# Altium schematic sheet Power.SchDot(Power)
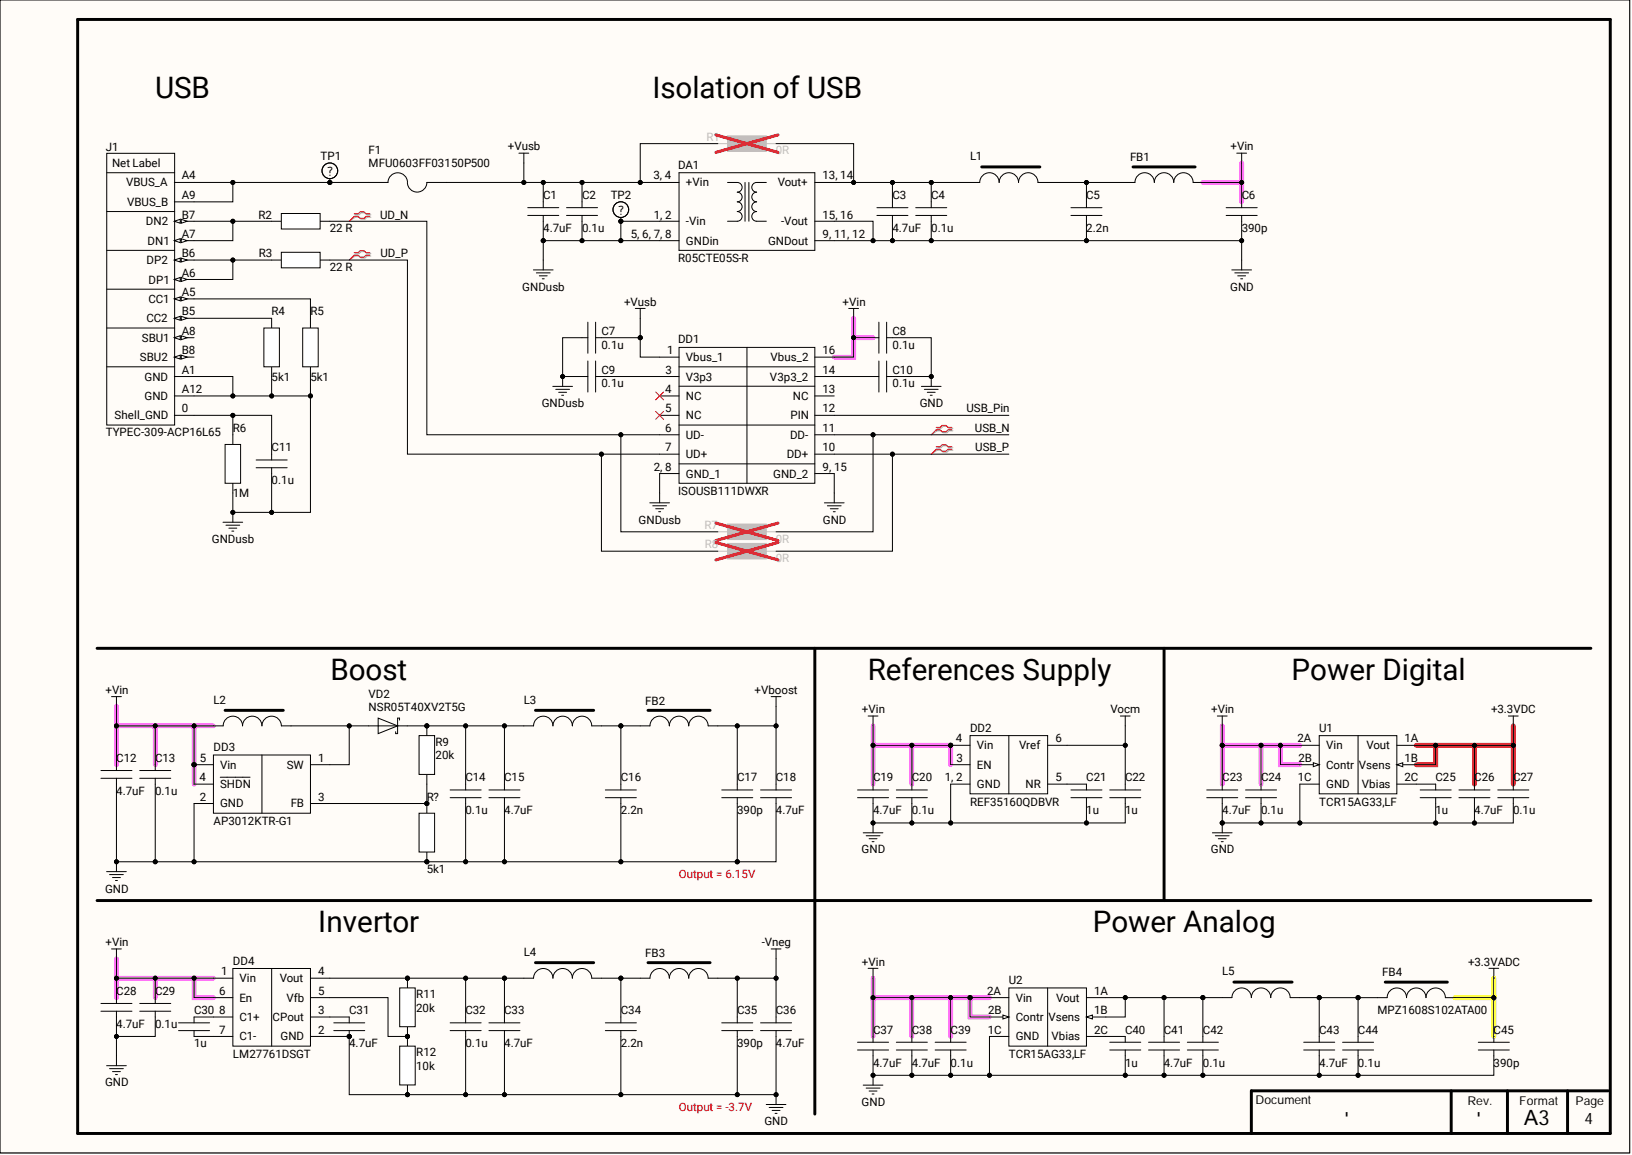
[diagram p0: Power.SchDot(Power)]

## Parts
C1  4.7uF  [C0603]
C2  0.1u  [C0603]
C3  4.7uF  [C0603]
C4  0.1u  [C0603]
C5  2.2n  [C0603]
C6  390p  [C0603]
C7  0.1u  [C0603]
C8  0.1u  [C0603]
C9  0.1u  [C0603]
C10  0.1u  [C0603]
C11  0.1u  [C0603]
C12  4.7uF  [C0603]
C13  0.1u  [C0603]
C14  0.1u  [C0603]
C15  4.7uF  [C0603]
C16  2.2n  [C0603]
C17  390p  [C0603]
C18  4.7uF  [C0603]
C19  4.7uF  [C0603]
C20  0.1u  [C0603]
C21  1u  [C0603]
C22  1u  [C0603]
C23  4.7uF  [C0603]
C24  0.1u  [C0603]
C25  1u  [C0603]
C26  4.7uF  [C0603]
C27  0.1u  [C0603]
C28  4.7uF  [C0603]
C29  0.1u  [C0603]
C30  1u  [C0603]
C31  4.7uF  [C0603]
C32  0.1u  [C0603]
C33  4.7uF  [C0603]
C34  2.2n  [C0603]
C35  390p  [C0603]
C36  4.7uF  [C0603]
C37  4.7uF  [C0603]
C38  4.7uF  [C0603]
C39  0.1u  [C0603]
C40  1u  [C0603]
C41  4.7uF  [C0603]
C42  0.1u  [C0603]
C43  4.7uF  [C0603]
C44  0.1u  [C0603]
C45  390p  [C0603]
DA1  R05CTE05S-R  [SOIC-16]
DD1  ISOUSB111DWXR  [SSOP-16]
DD2  REF35160QDBVR  [SOT-23-6]
DD3  AP3012KTR-G1  [SOT-23-5]
DD4  LM27761DSGT  [WSON-8]
F1  MFU0603FF03150P500  [F0603]
FB1  MPZ1608S102ATA00  [L0603]
FB2  MPZ1608S102ATA00  [L0603]
FB3  MPZ1608S102ATA00  [L0603]
FB4  MPZ1608S102ATA00  [L0603]
J1  TYPEC-309-ACP16L65  [217179-0001]
L1  AOTA-B252010S100MT  [0907 (2520)]  (value not drawn)
L2  AOTA-B252010S100MT  [0907 (2520)]  (value not drawn)
L3  AOTA-B252010S100MT  [0907 (2520)]  (value not drawn)
L4  AOTA-B252010S100MT  [0907 (2520)]  (value not drawn)
L5  AOTA-B252010S100MT  [0907 (2520)]  (value not drawn)
R1  0R  [R0603]
R2  22 R  [R0603]
R3  22 R  [R0603]
R4  5k1  [R0603]
R5  5k1  [R0603]
R6  1M  [R0603]
R7  0R  [R0603]
R8  0R  [R0603]
R9  20k  [R0603]
R11  20k  [R0603]
R12  10k  [R0603]
R?  5k1  [R0603]
TP1  5117  [36-5117-ND]  (value not drawn)
TP2  5117  [36-5117-ND]  (value not drawn)
U1  TCR15AG33,LF  [WCSP-6F-6]
U2  TCR15AG33,LF  [WCSP-6F-6]
VD2  NSR05T40XV2T5G  [SOD-523-2]

## Nets
+3.3VADC: C45.1 FB4.1
+3.3VDC: C26.1 C27.1 U1.1A(Vout) U1.1B(Vsens)
+Vboost: C17.1 C18.1 FB2.1
+Vin: C6.1 C8.1 C12.1 C13.1 C19.1 C20.1 C23.1 C24.1 C28.1 C29.1 C37.1 C38.1 C39.1 DD1.16(Vbus_2) DD2.3(EN) DD2.4(Vin) DD3.4(SHDN) DD3.5(Vin) DD4.1(Vin) DD4.6(En) FB1.1 L2.1 U1.2A(Vin) U1.2B(Contr) U2.2A(Vin) U2.2B(Contr)
+Vusb: C1.1 C2.1 C7.1 DA1.3, 4(+Vin) DD1.1(Vbus_1) F1.2 R1.1
-Vneg: C35.1 C36.1 FB3.1
GND: C3.2 C4.2 C5.2 C6.2 C8.2 C10.1 C12.2 C13.2 C14.2 C15.2 C16.2 C17.2 C18.2 C19.2 C20.2 C21.1 C22.1 C23.2 C24.2 C25.1 C26.2 C27.2 C28.2 C29.2 C31.2 C32.2 C33.2 C34.2 C35.2 C36.2 C37.2 C38.2 C39.2 C40.1 C41.2 C42.2 C43.2 C44.2 C45.2 DA1.9, 11, 12(GNDout) DA1.15, 16(-Vout) DD1.9, 15(GND_2) DD2.1, 2(GND) DD3.2(GND) DD4.2(GND) R12.1 R?.2 U1.1C(GND) U2.1C(GND)
GNDusb: C1.2 C2.2 C7.2 C9.2 C11.2 DA1.1, 2(-Vin) DA1.5, 6, 7, 8(GNDin) DD1.2, 8(GND_1) J1.A1(GND) J1.A12(GND) R4.2 R5.2 R6.2 TP2.1
Vocm: C22.2 DD2.6(Vref)
UD_N: DD1.6(UD-) R2.2 R7.1
UD_P: DD1.7(UD+) R3.2 R8.1
USB_N: DD1.11(DD-) R7.2
USB_P: DD1.10(DD+) R8.2
USB_Pin: DD1.12(PIN)
NetC3_1: C3.1 C4.1 DA1.13, 14(Vout+) L1.1 R1.2
NetC5_1: C5.1 FB1.2 L1.2
NetC9_1: C9.1 DD1.3(V3p3)
NetC10_2: C10.2 DD1.14(V3p3_2)
NetC11_1: C11.1 J1.0(Shell_GND) R6.1
NetC14_1: C14.1 C15.1 L3.1 R9.2 VD2.2
NetC16_1: C16.1 FB2.2 L3.2
NetC21_2: C21.2 DD2.5(NR)
NetC25_2: C25.2 U1.2C(Vbias)
NetC30_1: C30.1 DD4.8(C1+)
NetC30_2: C30.2 DD4.7(C1-)
NetC31_1: C31.1 DD4.3(CPout)
NetC32_1: C32.1 C33.1 DD4.4(Vout) L4.1 R11.2
NetC34_1: C34.1 FB3.2 L4.2
NetC40_2: C40.2 U2.2C(Vbias)
NetC41_1: C41.1 C42.1 L5.1 U2.1A(Vout) U2.1B(Vsens)
NetC43_1: C43.1 C44.1 FB4.2 L5.2
NetDD3_1: DD3.1(SW) L2.2 VD2.1
NetDD3_3: DD3.3(FB) R9.1 R?.1
NetDD4_5: DD4.5(Vfb) R11.1 R12.2
NetF1_1: F1.1 J1.A4(VBUS_A) J1.A9(VBUS_B) TP1.1
NetJ1_A5: J1.A5(CC1) R5.1
NetJ1_A6: J1.A6(DP1) J1.B6(DP2) R3.1
NetJ1_A7: J1.A7(DN1) J1.B7(DN2) R2.1
NetJ1_B5: J1.B5(CC2) R4.1

Not connected: DD1 4(NC) 5(NC) 13(NC); J1 A8(SBU1) B8(SBU2)

## Library data of the parts
C1 C3 C12 C15 C18 C19 C23 C26 C28 C31 C33 C36-C38 C41 C43 = MLCC: 16V 4.7uF X7S ±10% 0603 Multilayer Ceramic Capacitors MLCC - SMD/SMT ROHS; Manufacturer: Murata Electronics; P/N: GRT188C71C475KE13D; Case: 0603; Component Kind: Standard; Component Type: MLCC; ComponentLink1Description: Link; ComponentLink2Description: Datasheet; Value: 4.7uF; Library Name: Scheet.SchLib
  C1 C3 C12 C15 C18 C28 C31 C33 C36: ComponentLink1URL: https://eu.mouser.com/ProductDetail/Murata-Electronics/GRT155C81A475ME13D?qs=ufv2HEpFn%252BRTWI1%252Bv%2F3NwA%3D%3D; ComponentLink2URL: https://eu.mouser.com/datasheet/2/281/1/GRT155C81A475ME13_01A-3135426.pdf
C2 C4 C7-C11 C13 C14 C20 C24 C27 C29 C32 C39 C42 C44 = MLCC: 100V 100nF X7R ±10% 0603 Multilayer Ceramic Capacitors MLCC - SMD/SMT ROHS; Manufacturer: Kyocera AVX; P/N: 06031C104K4T2A; Case: 0603; Component Kind: Standard; Component Type: MLCC; ComponentLink1Description: Link; ComponentLink2Description: Datasheet; Value: 0.1u; Library Name: Scheet.SchLib
  C2 C7 C9-C11: ComponentLink1URL: https://eu.mouser.com/ProductDetail/Murata-Electronics/GRM155C81H104ME14D?qs=IPQhFcwEUOignsDjW4gFkg%3D%3D; ComponentLink2URL: https://eu.mouser.com/datasheet/2/281/1/GRM155C81H104ME14_01A-1983691.pdf
C5 C16 C34 = MLCC: 50V 2.2nF X7R ±5% 0603 Multilayer Ceramic Capacitors MLCC - SMD/SMT ROHS; Manufacturer: Kyocera AVX; P/N: 06035C222JAT2A; Case: 0603; Component Kind: Standard; Component Type: MLCC; ComponentLink1Description: Link; ComponentLink2Description: Datasheet; Value: 2.2n; Library Name: Scheet.SchLib
C6 C17 C35 C45 = MLCC: 50V 390pF C0G ±5% 0603 Multilayer Ceramic Capacitors MLCC - SMD/SMT ROHS; Manufacturer: CCTC; P/N: TCC0603COG391J500CT; Case: 0603; Component Kind: Standard; Component Type: MLCC; ComponentLink1Description: Link; ComponentLink2Description: Datasheet; Value: 390p; Library Name: Scheet.SchLib
C21 C22 C25 C30 C40 = MLCC: 25V 1uF X7R ±10% 0603 Multilayer Ceramic Capacitors MLCC - SMD/SMT ROHS; Manufacturer: IHHEC(HOLY STONE ENTERPRISE CO., LTD); P/N: C0603X105K025T; Case: 0603; Component Kind: Standard; Component Type: MLCC; ComponentLink1Description: Link; ComponentLink2Description: Datasheet; Value: 1u; Library Name: Scheet.SchLib
  C21 C22 C40: ComponentLink1URL: https://eu.mouser.com/ProductDetail/Murata-Electronics/GCM155C71A105ME38D?qs=QzBtWTOodeV5ddl%2FvWs3LA%3D%3D; ComponentLink2URL: https://eu.mouser.com/datasheet/2/281/1/GCM155C71A105ME38_01A-3142248.pdf
  C25: ComponentLink2URL: https://eu.mouser.com/datasheet/2/281/1/GCM155C71A105ME38_01A-3142248.pdf
DA1 = R05CTE05S-R: Isolated DC/DC Converters - SMD 1W 4.5-5.5Vin 5.0Vout 200mA Reel; Manufacturer: RECOM; Value: 1 W, 5V; Case: SOIC-16; Component Kind: Standard; Component Type: Isolated DC/DC Converters - SMD; ComponentLink1Description: Datasheet; ComponentLink1URL: https://eu.mouser.com/datasheet/2/468/RxxCTExxS-3179767.pdf; ComponentLink2Description: Link; ComponentLink2URL: https://eu.mouser.com/ProductDetail/RECOM-Power/R05CTE05S-R?qs=7D1LtPJG0i0SUCKLd%252BnSSQ%3D%3D; P/N: R05CTE05S-R; Library Name: Scheet.SchLib
DD1 = ISOUSB111DWXR: USB Interface IC Low-emission isolated USB repeater supporting low and full speed 16-SSOP -40 to 125; Manufacturer: Texas Instruments; Value: USB 2.0; Case: SSOP-16; Component Kind: Standard; Component Type: USB Interface IC; ComponentLink1Description: Datasheet; ComponentLink1URL: https://www.ti.com/lit/ds/symlink/isousb111.pdf?ts=1708034758891&ref_url=https%253A%252F%252Fwww.ti.com%252Fproduct%252FISOUSB111; ComponentLink2Description: Link; ComponentLink2URL: https://eu.mouser.com/ProductDetail/Texas-Instruments/ISOUSB111DWXR?qs=Y0Uzf4wQF3msEozH7EwD3g%3D%3D; P/N: ISOUSB111DWXR; Library Name: Scheet.SchLib
DD2 = REF35160QDBVR: Voltage References 650-nA quiescent current, 12-ppm/C drift, ultra-low-power precision voltage reference; Manufacturer: Texas Instruments; Value: 1.6 V 0.05 %  12 PPM / C; Case: SOT-23-6; Component Kind: Standard; Component Type: Voltage References; ComponentLink1Description: Datasheet; ComponentLink1URL: https://www.ti.com/lit/ds/symlink/ref35.pdf?ts=1709124227613&ref_url=https%253A%252F%252Fwww.mouser.de%252F; ComponentLink2Description: Link; ComponentLink2URL: https://eu.mouser.com/ProductDetail/Texas-Instruments/REF35160QDBVR?qs=rQFj71Wb1eVJh8regkgQhg%3D%3D; P/N: REF35160QDBVR; Library Name: Scheet.SchLib
DD3 = AP3012KTR-G1: Switching Voltage Regulators 1.5MHz DC/DC 2.6V to 16V; Manufacturer: Diodes Incorporated; Case: SOT-23-5; Component Kind: Standard; Component Type: Switching Voltage Regulators; ComponentLink1Description: Datasheet; ComponentLink1URL: https://www.diodes.com/assets/Datasheets/AP3012.pdf; ComponentLink2Description: Link; ComponentLink2URL: https://eu.mouser.com/ProductDetail/Diodes-Incorporated/AP3012KTR-G1?qs=x6A8l6qLYDDQ%252B3KTjfzHFg%3D%3D; P/N: AP3012KTR-G1; Value: 16 V; Library Name: Scheet.SchLib
DD4 = LM27761DSGT: Switching Voltage Regulators Low-Noise Regulated Switched Capacitor; Manufacturer: Texas Instruments; Value: - 5 V to - 1.5 V; Case: WSON-8; Component Kind: Standard; Component Type: Switching Voltage Regulators; ComponentLink1Description: Datasheet; ComponentLink1URL: https://www.ti.com/lit/ds/symlink/lm27761.pdf?ts=1709149591323&ref_url=https%253A%252F%252Feu.mouser.com%252F; ComponentLink2Description: Link; ComponentLink2URL: https://eu.mouser.com/ProductDetail/Texas-Instruments/LM27761DSGT?qs=jK8VN%252BJp1h5Sdnd3ViQCNQ%3D%3D; P/N: LM27761DSGT; Library Name: Scheet.SchLib
F1 = MFU0603FF03150P500: Surface Mount Fuses 32V 3.15A Fast Act; Manufacturer: Vishay; Case: 0603; Component Kind: Standard; Component Type: Surface Mount Fuses; ComponentLink1Description: Datasheet; ComponentLink1URL: https://www.vishay.com/docs/28747/mfuserie.pdf; ComponentLink2Description: Link; ComponentLink2URL: https://eu.mouser.com/ProductDetail/Vishay-Beyschlag/MFU0603FF03150P500?qs=sGAEpiMZZMsIz3CjQ1xegdfpEu45NLwazbH8oP2SIn8%3D; P/N: MFU0603FF03150P500; Value: 3.15 A; Library Name: Scheet.SchLib
FB1-FB4 = MPZ1608S102ATA00: Ferrite Beads 1000ohm 0.8A 300mOhm 0603 Ferrite Chip; Manufacturer: TDK; Value: 1k; Case: 0603; Component Kind: Standard; Component Type: Ferrite Beads; ComponentLink1Description: Datasheet; ComponentLink1URL: https://product.tdk.com/system/files/dam/doc/product/emc/emc/beads/catalog/beads_commercial_power_mpz1608_en.pdf; ComponentLink2Description: Link; ComponentLink2URL: https://eu.mouser.com/ProductDetail/TDK/MPZ1608S102ATA00?qs=8Kz9Wu9QwqXjw56MUD5REw%3D%3D; P/N: MPZ1608S102ATA00; Library Name: Scheet.SchLib
J1 = 217179-0001: USB Connectors Top-Mnt SMT 16Ckt Type C Rec.; Manufacturer: XUNPU; Value: USB 2.0; Case: 16 Contact; Component Kind: Standard; Component Type: USB Connectors; ComponentLink1Description: Datasheet; ComponentLink1URL: https://www.molex.com/en-us/products/part-detail/2171790001; ComponentLink2Description: Link; ComponentLink2URL: https://eu.mouser.com/ProductDetail/Molex/217179-0001?qs=DRkmTr78QAQqCH4BoIR1xg%3D%3D; Library Name: Connectors.SchLib; P/N: TYPEC-309-ACP16L65
L1-L5 = AOTA-B252010S100MT: Power Inductors - SMD IND 10uH 1.2A 420mOhms; Manufacturer: ABRACON; Case: 0907 (2520 metric); Component Kind: Standard; Component Type: Power Inductors - SMD; ComponentLink1Description: Datasheet; ComponentLink2Description: Link; P/N: AOTA-B252010S100MT; Value: 10 uH; Library Name: Scheet.SchLib
R1 R7 R8 = SMD Resistor: Res SMD; Manufacturer: VO; P/N: SCR0603J0R; Case: 0603; Component Kind: Standard; ComponentLink1Description: Datasheet; ComponentLink2Description: Link; Value: 0R; Library Name: Scheet.SchLib
  R7: ComponentLink1URL: https://www.lcsc.com/datasheet/lcsc_datasheet_2205131800_VO-SCR0603J0R_C3017805.pdf; ComponentLink2URL: https://www.lcsc.com/product-detail/Chip-span-style-background-color-ff0-Resistor-span-Surface-Mount_VO-SCR0603J0R_C3017805.html
R2 R3 = SMD Resistor: Res SMD; Manufacturer: VO; P/N: SCR0603J22R; Case: 0603; Component Kind: Standard; ComponentLink1Description: Datasheet; ComponentLink2Description: Link; Value: 22 R; Library Name: Scheet.SchLib
  R2: ComponentLink1URL: https://eu.mouser.com/datasheet/2/315/AOA0000C301-1488782.pdf; ComponentLink2URL: https://eu.mouser.com/ProductDetail/Panasonic/ERJ-2GEJ220X?qs=sGAEpiMZZMvdGkrng054t8ugkoYZivkgE05%252BFXPHW90%3D
R4 R5 R? = SMD Resistor: 100mW Thick Film Resistors 75V ±1% 5.1kΩ 0603 Chip Resistor - Surface Mount ROHS; Manufacturer: VO; P/N: SCR0603F5K1; Case: 0603; Component Kind: Standard; ComponentLink1Description: Datasheet; ComponentLink1URL: https://eu.mouser.com/datasheet/2/315/AOA0000C304-1149620.pdf; ComponentLink2Description: Link; ComponentLink2URL: https://eu.mouser.com/ProductDetail/Panasonic/ERJ-2RKF2202X?qs=sGAEpiMZZMvdGkrng054t8AJgcdMkx7xGCv6QlnvaAM%3D; Value: 5k1; Library Name: Scheet.SchLib
R6 = SMD Resistor: 100mW ±25ppm/℃ ±0.1% 1MΩ 0603 Chip Resistor - Surface Mount ROHS; Manufacturer: Viking Tech; P/N: AR03BTCX1004; Case: 0603; Component Kind: Standard; ComponentLink1Description: Datasheet; ComponentLink1URL: https://www.lcsc.com/datasheet/lcsc_datasheet_2308241947_FOJAN-FRC0603J105-TS_C2907115.pdf; ComponentLink2Description: Link; ComponentLink2URL: https://www.lcsc.com/product-detail/Chip-span-style-background-color-ff0-Resistor-span-Surface-Mount_FOJAN-FRC0603J105-TS_C2907115.html; Value: 1M; Library Name: Scheet.SchLib
R9 R11 = SMD Resistor: 100mW Thick Film Resistors 75V ±5% 20kΩ 0603 Chip Resistor - Surface Mount ROHS; Manufacturer: VO; P/N: SCR0603J20K; Case: 0603; Component Kind: Standard; ComponentLink1Description: Datasheet; ComponentLink2Description: Link; Value: 20k; Library Name: Scheet.SchLib
  R9: ComponentLink1URL: https://eu.mouser.com/datasheet/2/210/kamaya_products_RGC__english_20170424_101024-1651573.pdf; ComponentLink2URL: https://eu.mouser.com/ProductDetail/Kamaya/RGC1-16SC1001DTH?qs=KAq9QXcE8HAz3iV8i32W5A%3D%3D
R12 = SMD Resistor: 100mW Thick Film Resistors 75V ±5% 10kΩ 0603 Chip Resistor - Surface Mount ROHS; Manufacturer: VO; P/N: SCR0603J10K; Case: 0603; Component Kind: Standard; ComponentLink1Description: Datasheet; ComponentLink2Description: Link; Value: 10k; Library Name: Scheet.SchLib
TP1 TP2 = (no symbol name): PCB TEST POINT BLUE; Manufacturer: Keystone Electronics; Value: 36-5117; Case: THT; Component Kind: Standard; Component Type: Test point; ComponentLink1Description: Datasheet; ComponentLink1URL: https://ru.mouser.com/datasheet/2/215/5115_5119-744073.pdf; ComponentLink2Description: Link; ComponentLink2URL: https://ru.mouser.com/ProductDetail/Keystone-Electronics/5117?qs=%2Fha2pyFadujSuVybhyFc6oqj%252BXCGMBBx2uPmIz4OBqU%3D; Library Name: Others.SchLib; P/N: 5117
U1 U2 = TCR15AG33,LF: LDO Voltage Regulators LDO Linear Voltage Regulator 1.5A 3.3V; Manufacturer: Toshiba; Value: 3V3, 1.5A; Case: WCSP-6F-6; Component Kind: Standard; Component Type: LDO Voltage Regulators; ComponentLink1Description: Datasheet; ComponentLink1URL: https://eu.mouser.com/datasheet/2/408/TCR15AG33_datasheet_en_20230824-1916466.pdf; ComponentLink2Description: Link; ComponentLink2URL: https://eu.mouser.com/ProductDetail/Toshiba/TCR15AG33LF?qs=W0yvOO0ixfEdwQi51FhISQ%3D%3D; P/N: TCR15AG33,LF; Library Name: Scheet.SchLib
VD2 = NSR05T40XV2T5G: Schottky Diodes & Rectifiers TRENCH SCHOTTKY TI BARRIER FOR BOOST CONVERTERS LOW POWER; Manufacturer: onsemi; Value: 500 mA; Case: SOD-523-2; Component Kind: Standard; Component Type: Schottky Diodes & Rectifiers; ComponentLink1Description: Datasheet; ComponentLink1URL: https://www.mouser.de/datasheet/2/308/1/NSR05T40XV2_D-2318283.pdf; ComponentLink2Description: Link; ComponentLink2URL: https://www.mouser.de/ProductDetail/onsemi/NSR05T40XV2T5G?qs=8hV9pjh0QhPa2G06GHkD%2FQ%3D%3D; Library Name: Diodes.SchLib; P/N: NSR05T40XV2T5G
